# Altium schematic sheet DDR3.SchDoc(DDR3)
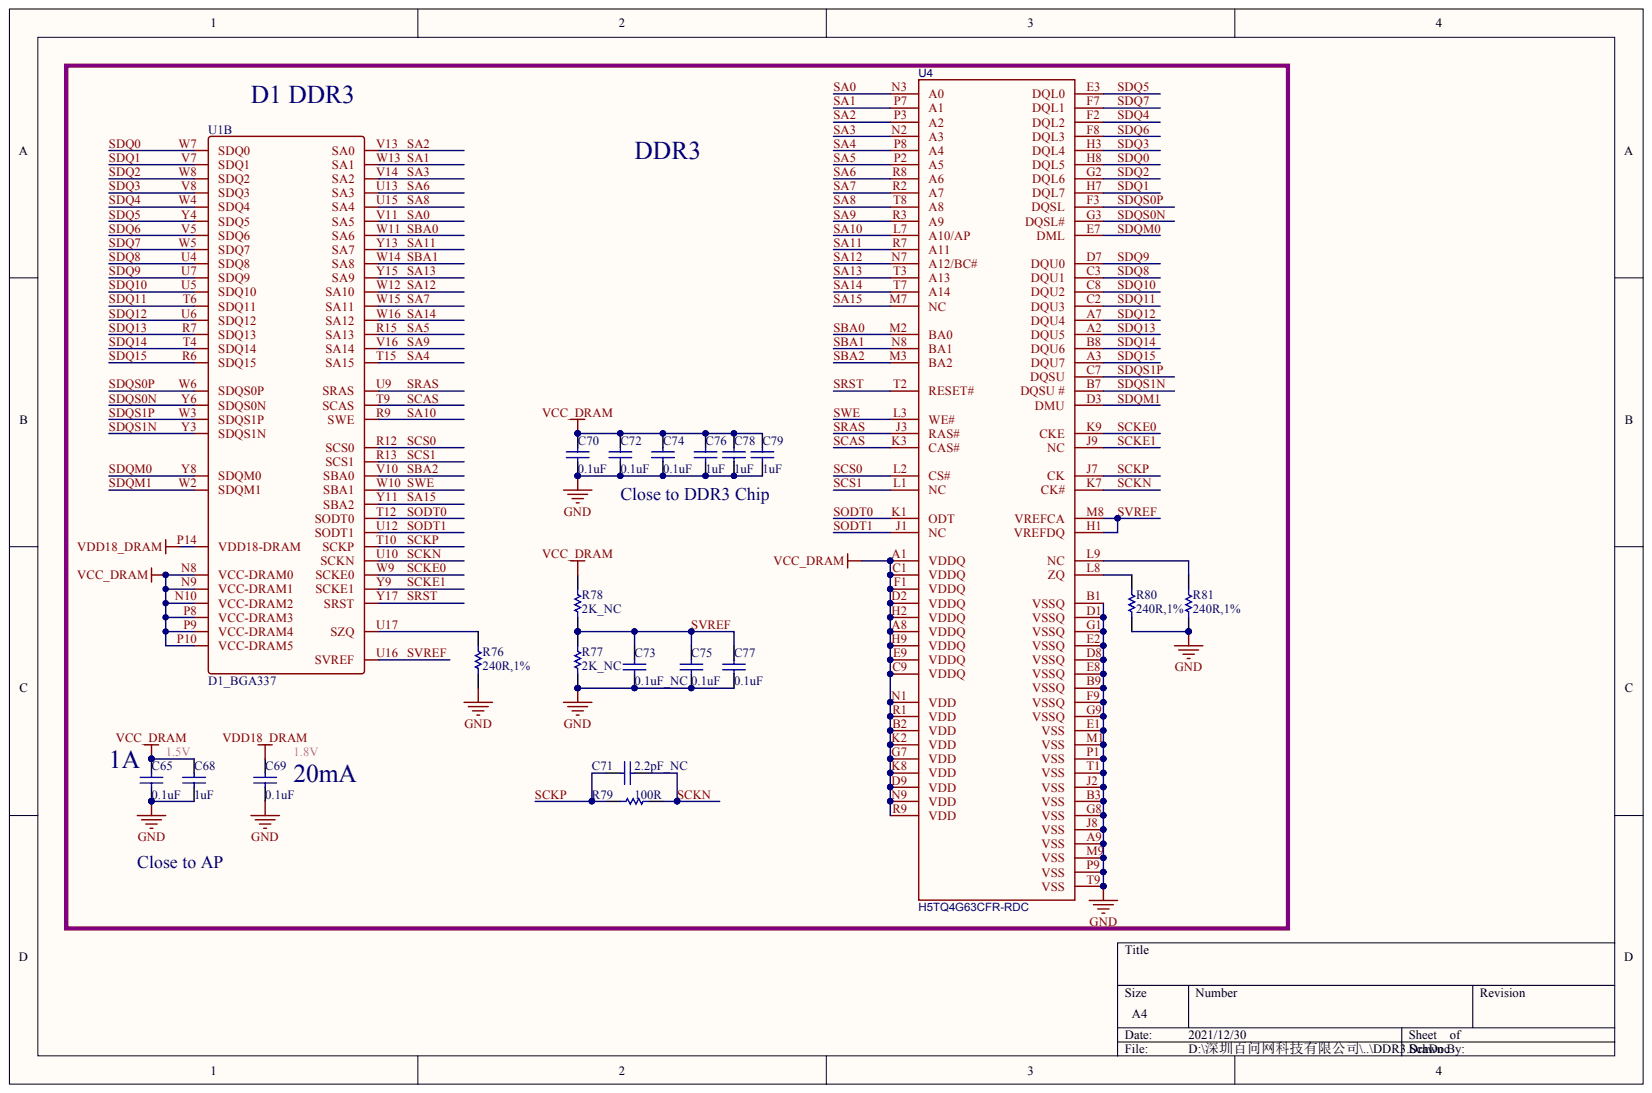
[diagram p0: DDR3.SchDoc]

## Parts
C65  0.1uF
C68  1uF
C69  0.1uF
C70  0.1uF
C71  2.2pF_NC
C72  0.1uF
C73  0.1uF_NC
C74  0.1uF
C75  0.1uF
C76  1uF
C77  0.1uF
C78  1uF
C79  1uF
R76  240R,1%
R77  2K_NC
R78  2K_NC
R79  100R
R80  240R,1%
R81  240R,1%
U1  D1_BGA337  (part B)
U4  H5TQ4G63CFR-RDC

## Nets
GND: C65.1 C68.1 C69.1 C70.1 C72.1 C73.1 C74.1 C75.1 C76.1 C77.1 C78.1 C79.1 R76.2 R77.1 R80.1 R81.1 U4.A9(VSS) U4.B1(VSSQ) U4.B3(VSS) U4.B9(VSSQ) U4.D1(VSSQ) U4.D8(VSSQ) U4.E1(VSS) U4.E2(VSSQ) U4.E8(VSSQ) U4.F9(VSSQ) U4.G1(VSSQ) U4.G8(VSS) U4.G9(VSSQ) U4.J2(VSS) U4.J8(VSS) U4.M1(VSS) U4.M9(VSS) U4.P1(VSS) U4.P9(VSS) U4.T1(VSS) U4.T9(VSS)
VCC_DRAM: C65.2 C68.2 C70.2 C72.2 C74.2 C76.2 C78.2 C79.2 R78.2 U1.N8(VCC-DRAM0) U1.N9(VCC-DRAM1) U1.N10(VCC-DRAM2) U1.P8(VCC-DRAM3) U1.P9(VCC-DRAM4) U1.P10(VCC-DRAM5) U4.A1(VDDQ) U4.A8(VDDQ) U4.B2(VDD) U4.C1(VDDQ) U4.C9(VDDQ) U4.D2(VDDQ) U4.D9(VDD) U4.E9(VDDQ) U4.F1(VDDQ) U4.G7(VDD) U4.H2(VDDQ) U4.H9(VDDQ) U4.K2(VDD) U4.K8(VDD) U4.N1(VDD) U4.N9(VDD) U4.R1(VDD) U4.R9(VDD)
VDD18_DRAM: C69.2 U1.P14(VDD18-DRAM)
SA0: U1.V11(SA5) U4.N3(A0)
SA1: U1.W13(SA1) U4.P7(A1)
SA2: U1.V13(SA0) U4.P3(A2)
SA3: U1.V14(SA2) U4.N2(A3)
SA4: U1.T15(SA15) U4.P8(A4)
SA5: U1.R15(SA13) U4.P2(A5)
SA6: U1.U13(SA3) U4.R8(A6)
SA7: U1.W15(SA11) U4.R2(A7)
SA8: U1.U15(SA4) U4.T8(A8)
SA9: U1.V16(SA14) U4.R3(A9)
SA10: U1.R9(SWE) U4.L7(A10/AP)
SA11: U1.Y13(SA7) U4.R7(A11)
SA12: U1.W12(SA10) U4.N7(A12/BC#)
SA13: U1.Y15(SA9) U4.T3(A13)
SA14: U1.W16(SA12) U4.T7(A14)
SA15: U1.Y11(SBA2) U4.M7(NC)
SBA0: U1.W11(SA6) U4.M2(BA0)
SBA1: U1.W14(SA8) U4.N8(BA1)
SBA2: U1.V10(SBA0) U4.M3(BA2)
SCAS: U1.T9(SCAS) U4.K3(CAS#)
SCKE0: U1.W9(SCKE0) U4.K9(CKE)
SCKE1: U1.Y9(SCKE1) U4.J9(NC)
SCKN: C71.1 R79.1 U1.U10(SCKN) U4.K7(CK#)
SCKP: C71.2 R79.2 U1.T10(SCKP) U4.J7(CK)
SCS0: U1.R12(SCS0) U4.L2(CS#)
SCS1: U1.R13(SCS1) U4.L1(NC)
SDQ0: U1.W7(SDQ0) U4.H8(DQL5)
SDQ1: U1.V7(SDQ1) U4.H7(DQL7)
SDQ2: U1.W8(SDQ2) U4.G2(DQL6)
SDQ3: U1.V8(SDQ3) U4.H3(DQL4)
SDQ4: U1.W4(SDQ4) U4.F2(DQL2)
SDQ5: U1.Y4(SDQ5) U4.E3(DQL0)
SDQ6: U1.V5(SDQ6) U4.F8(DQL3)
SDQ7: U1.W5(SDQ7) U4.F7(DQL1)
SDQ8: U1.U4(SDQ8) U4.C3(DQU1)
SDQ9: U1.U7(SDQ9) U4.D7(DQU0)
SDQ10: U1.U5(SDQ10) U4.C8(DQU2)
SDQ11: U1.T6(SDQ11) U4.C2(DQU3)
SDQ12: U1.U6(SDQ12) U4.A7(DQU4)
SDQ13: U1.R7(SDQ13) U4.A2(DQU5)
SDQ14: U1.T4(SDQ14) U4.B8(DQU6)
SDQ15: U1.R6(SDQ15) U4.A3(DQU7)
SDQM0: U1.Y8(SDQM0) U4.E7(DML)
SDQM1: U1.W2(SDQM1) U4.D3(DMU)
SDQS0N: U1.Y6(SDQS0N) U4.G3(DQSL#)
SDQS0P: U1.W6(SDQS0P) U4.F3(DQSL)
SDQS1N: U1.Y3(SDQS1N) U4.B7(DQSU_#)
SDQS1P: U1.W3(SDQS1P) U4.C7(DQSU)
SODT0: U1.T12(SODT0) U4.K1(ODT)
SODT1: U1.U12(SODT1) U4.J1(NC)
SRAS: U1.U9(SRAS) U4.J3(RAS#)
SRST: U1.Y17(SRST) U4.T2(RESET#)
SVREF: C73.2 C75.2 C77.2 R77.2 R78.1 U1.U16(SVREF) U4.H1(VREFDQ) U4.M8(VREFCA)
SWE: U1.W10(SBA1) U4.L3(WE#)
NetR76_1: R76.1 U1.U17(SZQ)
NetR80_2: R80.2 U4.L8(ZQ)
NetR81_2: R81.2 U4.L9(NC)

## Library data of the parts
C65 C68-C79 = (no symbol name): PartNumber: CAP100NF; Published: 8-Jun-2000; LatestRevisionDate: 17-Jul-2002; LatestRevisionNote: Re-released for DXP Platform.; PackageReference: 0402; Publisher: Altium Limited
  C65 C69 C70 C72 C74 C75 C77: Value: 0.1uF
  C68 C76 C78 C79: Value: 1uF
  C71: Value: 2.2pF_NC
  C73: Value: 0.1uF_NC
R76-R81 = (no symbol name): PartNumber: RES2.2K; Published: 8-Jun-2000; LatestRevisionDate: 17-Jul-2002; LatestRevisionNote: Re-released for DXP Platform.; PackageReference: 0402; Publisher: Altium Limited
  R76 R80 R81: Value: 240R,1%
  R77 R78: Value: 2K_NC
  R79: Value: 100R
U4 = (no symbol name): BOM_Manufacturer: SK HYNIX; Contributor: LCSC; BOM_Supplier: LCSC; BOM_Supplier Part: C390898; BOM_Manufacturer Part: H5TQ4G63CFR-RDC; BOM_JLCPCB Part Class: Extended Part; spicePre: U; spiceSymbolName: H5TQ4G63CFR-RDC
